# Altium schematic sheet 419-005-01-02_PG 05 - CV SoC - HPS DDR.SchDoc("419-005-01-02_PG 05 - CV SoC - HPS DDR")
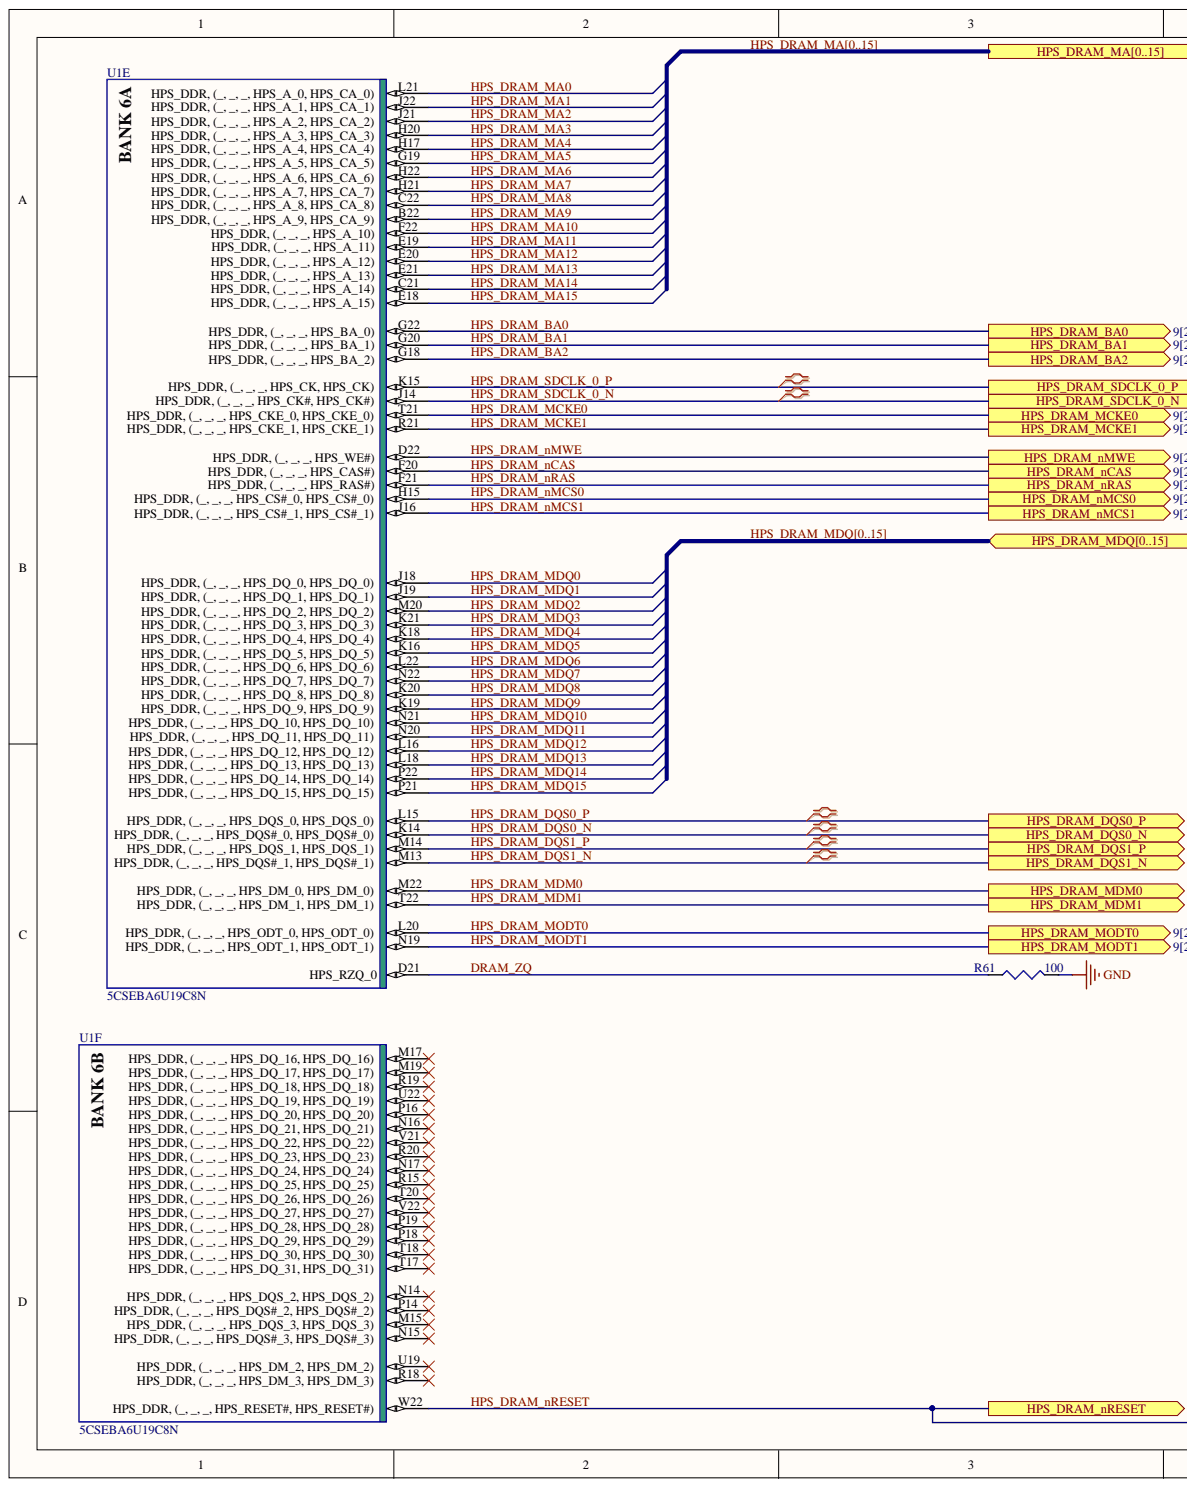
[diagram p0: 419-005-01-02_PG 05 - CV SoC - HPS DDR.SchDoc, left]
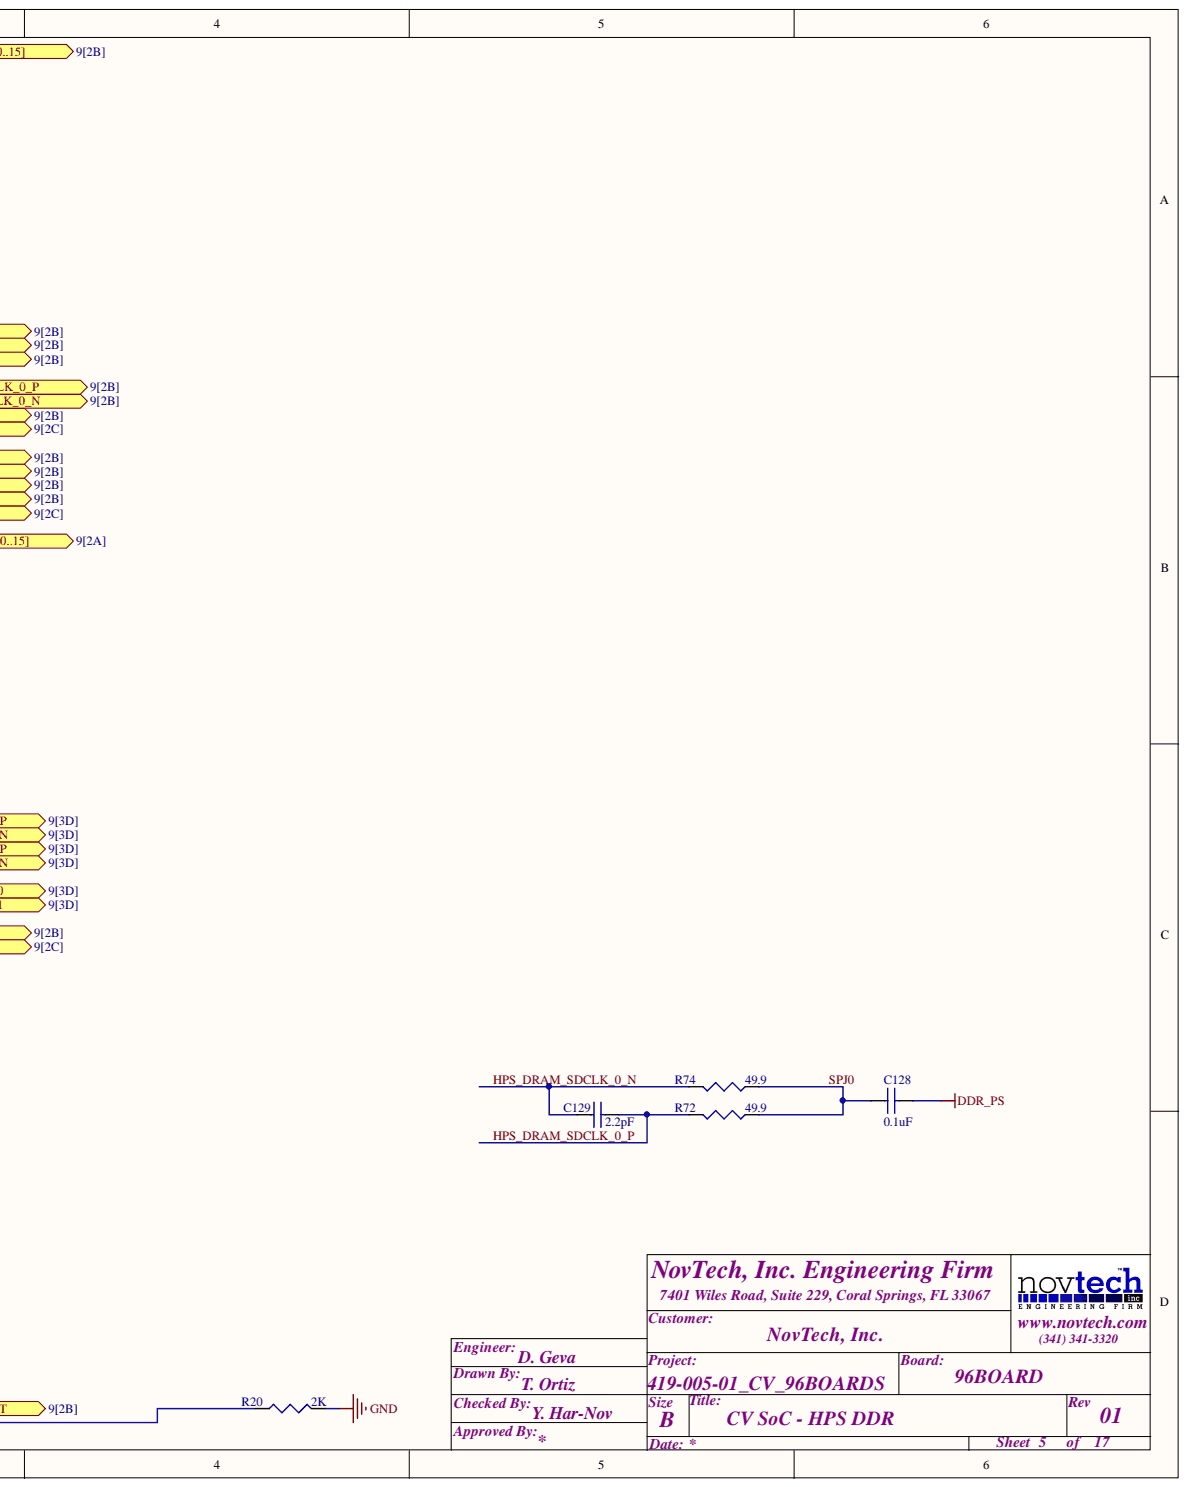
[diagram p1: 419-005-01-02_PG 05 - CV SoC - HPS DDR.SchDoc, right]

## Parts
C128  0.1uF  [0201_C]
C129  2.2pF  [0201_C]
R20  2K  [0201_R]
R61  100  [0201_R]
R72  49.9  [0402_R]
R74  49.9  [0402_R]
U1  5CSEBA6U19C8N  [BGA484C80P22X22_1900X1900X190]  (parts E,F)

## Ports
HPS_DRAM_BA0, HPS_DRAM_BA1, HPS_DRAM_BA2, HPS_DRAM_DQS0_N, HPS_DRAM_DQS0_P, HPS_DRAM_DQS1_N, HPS_DRAM_DQS1_P, HPS_DRAM_MA0, HPS_DRAM_MA1, HPS_DRAM_MA2, HPS_DRAM_MA3, HPS_DRAM_MA4, HPS_DRAM_MA5, HPS_DRAM_MA6, HPS_DRAM_MA7, HPS_DRAM_MA8, HPS_DRAM_MA9, HPS_DRAM_MA10, HPS_DRAM_MA11, HPS_DRAM_MA12, HPS_DRAM_MA13, HPS_DRAM_MA14, HPS_DRAM_MA15, HPS_DRAM_MA[0..15], HPS_DRAM_MCKE0, HPS_DRAM_MCKE1, HPS_DRAM_MDM0, HPS_DRAM_MDM1, HPS_DRAM_MDQ0, HPS_DRAM_MDQ1, HPS_DRAM_MDQ2, HPS_DRAM_MDQ3, HPS_DRAM_MDQ4, HPS_DRAM_MDQ5, HPS_DRAM_MDQ6, HPS_DRAM_MDQ7, HPS_DRAM_MDQ8, HPS_DRAM_MDQ9, HPS_DRAM_MDQ10, HPS_DRAM_MDQ11, HPS_DRAM_MDQ12, HPS_DRAM_MDQ13, HPS_DRAM_MDQ14, HPS_DRAM_MDQ15, HPS_DRAM_MDQ[0..15], HPS_DRAM_MODT0, HPS_DRAM_MODT1, HPS_DRAM_NCAS, HPS_DRAM_NMCS0, HPS_DRAM_NMCS1, HPS_DRAM_NMWE, HPS_DRAM_NRAS, HPS_DRAM_NRESET, HPS_DRAM_SDCLK_0_N, HPS_DRAM_SDCLK_0_P

## Nets
DDR_PS: C128.2
GND: R20.2 R61.2
DRAM_ZQ: R61.1 U1.D21(HPS_RZQ_0)
HPS_DRAM_BA0: U1.G22(HPS_DDR,_(_,__,__,_HPS_BA_0)) ; port HPS_DRAM_BA0
HPS_DRAM_BA1: U1.G20(HPS_DDR,_(_,__,__,_HPS_BA_1)) ; port HPS_DRAM_BA1
HPS_DRAM_BA2: U1.G18(HPS_DDR,_(_,__,__,_HPS_BA_2)) ; port HPS_DRAM_BA2
HPS_DRAM_DQS0_N: U1.K14(HPS_DDR,_(_,__,__,_HPS_DQS#_0,_HPS_DQS#_0)) ; port HPS_DRAM_DQS0_N
HPS_DRAM_DQS0_P: U1.L15(HPS_DDR,_(_,__,__,_HPS_DQS_0,_HPS_DQS_0)) ; port HPS_DRAM_DQS0_P
HPS_DRAM_DQS1_N: U1.M13(HPS_DDR,_(_,__,__,_HPS_DQS#_1,_HPS_DQS#_1)) ; port HPS_DRAM_DQS1_N
HPS_DRAM_DQS1_P: U1.M14(HPS_DDR,_(_,__,__,_HPS_DQS_1,_HPS_DQS_1)) ; port HPS_DRAM_DQS1_P
HPS_DRAM_MA0: U1.L21(HPS_DDR,_(_,__,__,_HPS_A_0,_HPS_CA_0)) ; port HPS_DRAM_MA[0..15]  [also labelled HPS_DRAM_MA]
HPS_DRAM_MA1: U1.J22(HPS_DDR,_(_,__,__,_HPS_A_1,_HPS_CA_1)) ; port HPS_DRAM_MA[0..15]  [also labelled HPS_DRAM_MA]
HPS_DRAM_MA2: U1.J21(HPS_DDR,_(_,__,__,_HPS_A_2,_HPS_CA_2)) ; port HPS_DRAM_MA[0..15]  [also labelled HPS_DRAM_MA]
HPS_DRAM_MA3: U1.H20(HPS_DDR,_(_,__,__,_HPS_A_3,_HPS_CA_3)) ; port HPS_DRAM_MA[0..15]  [also labelled HPS_DRAM_MA]
HPS_DRAM_MA4: U1.H17(HPS_DDR,_(_,__,__,_HPS_A_4,_HPS_CA_4)) ; port HPS_DRAM_MA[0..15]  [also labelled HPS_DRAM_MA]
HPS_DRAM_MA5: U1.G19(HPS_DDR,_(_,__,__,_HPS_A_5,_HPS_CA_5)) ; port HPS_DRAM_MA[0..15]  [also labelled HPS_DRAM_MA]
HPS_DRAM_MA6: U1.H22(HPS_DDR,_(_,__,__,_HPS_A_6,_HPS_CA_6)) ; port HPS_DRAM_MA[0..15]  [also labelled HPS_DRAM_MA]
HPS_DRAM_MA7: U1.H21(HPS_DDR,_(_,__,__,_HPS_A_7,_HPS_CA_7)) ; port HPS_DRAM_MA[0..15]  [also labelled HPS_DRAM_MA]
HPS_DRAM_MA8: U1.C22(HPS_DDR,_(_,__,__,_HPS_A_8,_HPS_CA_8)) ; port HPS_DRAM_MA[0..15]  [also labelled HPS_DRAM_MA]
HPS_DRAM_MA9: U1.B22(HPS_DDR,_(_,__,__,_HPS_A_9,_HPS_CA_9)) ; port HPS_DRAM_MA[0..15]  [also labelled HPS_DRAM_MA]
HPS_DRAM_MA10: U1.F22(HPS_DDR,_(_,__,__,_HPS_A_10)) ; port HPS_DRAM_MA[0..15]  [also labelled HPS_DRAM_MA]
HPS_DRAM_MA11: U1.E19(HPS_DDR,_(_,__,__,_HPS_A_11)) ; port HPS_DRAM_MA[0..15]  [also labelled HPS_DRAM_MA]
HPS_DRAM_MA12: U1.E20(HPS_DDR,_(_,__,__,_HPS_A_12)) ; port HPS_DRAM_MA[0..15]  [also labelled HPS_DRAM_MA]
HPS_DRAM_MA13: U1.E21(HPS_DDR,_(_,__,__,_HPS_A_13)) ; port HPS_DRAM_MA[0..15]  [also labelled HPS_DRAM_MA]
HPS_DRAM_MA14: U1.C21(HPS_DDR,_(_,__,__,_HPS_A_14)) ; port HPS_DRAM_MA[0..15]  [also labelled HPS_DRAM_MA]
HPS_DRAM_MA15: U1.E18(HPS_DDR,_(_,__,__,_HPS_A_15)) ; port HPS_DRAM_MA[0..15]  [also labelled HPS_DRAM_MA]
HPS_DRAM_MCKE0: U1.T21(HPS_DDR,_(_,__,__,_HPS_CKE_0,_HPS_CKE_0)) ; port HPS_DRAM_MCKE0
HPS_DRAM_MCKE1: U1.R21(HPS_DDR,_(_,__,__,_HPS_CKE_1,_HPS_CKE_1)) ; port HPS_DRAM_MCKE1
HPS_DRAM_MDM0: U1.M22(HPS_DDR,_(_,__,__,_HPS_DM_0,_HPS_DM_0)) ; port HPS_DRAM_MDM0
HPS_DRAM_MDM1: U1.T22(HPS_DDR,_(_,__,__,_HPS_DM_1,_HPS_DM_1)) ; port HPS_DRAM_MDM1
HPS_DRAM_MDQ0: U1.J18(HPS_DDR,_(_,__,__,_HPS_DQ_0,_HPS_DQ_0)) ; port HPS_DRAM_MDQ[0..15]  [also labelled HPS_DRAM_MDQ]
HPS_DRAM_MDQ1: U1.J19(HPS_DDR,_(_,__,__,_HPS_DQ_1,_HPS_DQ_1)) ; port HPS_DRAM_MDQ[0..15]  [also labelled HPS_DRAM_MDQ]
HPS_DRAM_MDQ2: U1.M20(HPS_DDR,_(_,__,__,_HPS_DQ_2,_HPS_DQ_2)) ; port HPS_DRAM_MDQ[0..15]  [also labelled HPS_DRAM_MDQ]
HPS_DRAM_MDQ3: U1.K21(HPS_DDR,_(_,__,__,_HPS_DQ_3,_HPS_DQ_3)) ; port HPS_DRAM_MDQ[0..15]  [also labelled HPS_DRAM_MDQ]
HPS_DRAM_MDQ4: U1.K18(HPS_DDR,_(_,__,__,_HPS_DQ_4,_HPS_DQ_4)) ; port HPS_DRAM_MDQ[0..15]  [also labelled HPS_DRAM_MDQ]
HPS_DRAM_MDQ5: U1.K16(HPS_DDR,_(_,__,__,_HPS_DQ_5,_HPS_DQ_5)) ; port HPS_DRAM_MDQ[0..15]  [also labelled HPS_DRAM_MDQ]
HPS_DRAM_MDQ6: U1.L22(HPS_DDR,_(_,__,__,_HPS_DQ_6,_HPS_DQ_6)) ; port HPS_DRAM_MDQ[0..15]  [also labelled HPS_DRAM_MDQ]
HPS_DRAM_MDQ7: U1.N22(HPS_DDR,_(_,__,__,_HPS_DQ_7,_HPS_DQ_7)) ; port HPS_DRAM_MDQ[0..15]  [also labelled HPS_DRAM_MDQ]
HPS_DRAM_MDQ8: U1.K20(HPS_DDR,_(_,__,__,_HPS_DQ_8,_HPS_DQ_8)) ; port HPS_DRAM_MDQ[0..15]  [also labelled HPS_DRAM_MDQ]
HPS_DRAM_MDQ9: U1.K19(HPS_DDR,_(_,__,__,_HPS_DQ_9,_HPS_DQ_9)) ; port HPS_DRAM_MDQ[0..15]  [also labelled HPS_DRAM_MDQ]
HPS_DRAM_MDQ10: U1.N21(HPS_DDR,_(_,__,__,_HPS_DQ_10,_HPS_DQ_10)) ; port HPS_DRAM_MDQ[0..15]  [also labelled HPS_DRAM_MDQ]
HPS_DRAM_MDQ11: U1.N20(HPS_DDR,_(_,__,__,_HPS_DQ_11,_HPS_DQ_11)) ; port HPS_DRAM_MDQ[0..15]  [also labelled HPS_DRAM_MDQ]
HPS_DRAM_MDQ12: U1.L16(HPS_DDR,_(_,__,__,_HPS_DQ_12,_HPS_DQ_12)) ; port HPS_DRAM_MDQ[0..15]  [also labelled HPS_DRAM_MDQ]
HPS_DRAM_MDQ13: U1.L18(HPS_DDR,_(_,__,__,_HPS_DQ_13,_HPS_DQ_13)) ; port HPS_DRAM_MDQ[0..15]  [also labelled HPS_DRAM_MDQ]
HPS_DRAM_MDQ14: U1.P22(HPS_DDR,_(_,__,__,_HPS_DQ_14,_HPS_DQ_14)) ; port HPS_DRAM_MDQ[0..15]  [also labelled HPS_DRAM_MDQ]
HPS_DRAM_MDQ15: U1.P21(HPS_DDR,_(_,__,__,_HPS_DQ_15,_HPS_DQ_15)) ; port HPS_DRAM_MDQ[0..15]  [also labelled HPS_DRAM_MDQ]
HPS_DRAM_MODT0: U1.L20(HPS_DDR,_(_,__,__,_HPS_ODT_0,_HPS_ODT_0)) ; port HPS_DRAM_MODT0
HPS_DRAM_MODT1: U1.N19(HPS_DDR,_(_,__,__,_HPS_ODT_1,_HPS_ODT_1)) ; port HPS_DRAM_MODT1
HPS_DRAM_NCAS: U1.F20(HPS_DDR,_(_,__,__,_HPS_CAS#)) ; port HPS_DRAM_NCAS
HPS_DRAM_NMCS0: U1.H15(HPS_DDR,_(_,__,__,_HPS_CS#_0,_HPS_CS#_0)) ; port HPS_DRAM_NMCS0
HPS_DRAM_NMCS1: U1.J16(HPS_DDR,_(_,__,__,_HPS_CS#_1,_HPS_CS#_1)) ; port HPS_DRAM_NMCS1
HPS_DRAM_NMWE: U1.D22(HPS_DDR,_(_,__,__,_HPS_WE#)) ; port HPS_DRAM_NMWE
HPS_DRAM_NRAS: U1.F21(HPS_DDR,_(_,__,__,_HPS_RAS#)) ; port HPS_DRAM_NRAS
HPS_DRAM_NRESET: R20.1 U1.W22(HPS_DDR,_(_,__,__,_HPS_RESET#,_HPS_RESET#)) ; port HPS_DRAM_NRESET
HPS_DRAM_SDCLK_0_N: C129.1 R74.2 U1.J14(HPS_DDR,_(_,__,__,_HPS_CK#,_HPS_CK#)) ; port HPS_DRAM_SDCLK_0_N
HPS_DRAM_SDCLK_0_P: C129.2 R72.2 U1.K15(HPS_DDR,_(_,__,__,_HPS_CK,_HPS_CK)) ; port HPS_DRAM_SDCLK_0_P
SPJ0: C128.1 R72.1 R74.1

Not connected: U1 M15(HPS_DDR,_(_,__,__,_HPS_DQS_3,_HPS_DQS_3)) M17(HPS_DDR,_(_,__,__,_HPS_DQ_16,_HPS_DQ_16)) M19(HPS_DDR,_(_,__,__,_HPS_DQ_17,_HPS_DQ_17)) N14(HPS_DDR,_(_,__,__,_HPS_DQS_2,_HPS_DQS_2)) N15(HPS_DDR,_(_,__,__,_HPS_DQS#_3,_HPS_DQS#_3)) N16(HPS_DDR,_(_,__,__,_HPS_DQ_21,_HPS_DQ_21)) N17(HPS_DDR,_(_,__,__,_HPS_DQ_24,_HPS_DQ_24)) P14(HPS_DDR,_(_,__,__,_HPS_DQS#_2,_HPS_DQS#_2)) P16(HPS_DDR,_(_,__,__,_HPS_DQ_20,_HPS_DQ_20)) P18(HPS_DDR,_(_,__,__,_HPS_DQ_29,_HPS_DQ_29)) P19(HPS_DDR,_(_,__,__,_HPS_DQ_28,_HPS_DQ_28)) R15(HPS_DDR,_(_,__,__,_HPS_DQ_25,_HPS_DQ_25)) R18(HPS_DDR,_(_,__,__,_HPS_DM_3,_HPS_DM_3)) R19(HPS_DDR,_(_,__,__,_HPS_DQ_18,_HPS_DQ_18)) R20(HPS_DDR,_(_,__,__,_HPS_DQ_23,_HPS_DQ_23)) T17(HPS_DDR,_(_,__,__,_HPS_DQ_31,_HPS_DQ_31)) T18(HPS_DDR,_(_,__,__,_HPS_DQ_30,_HPS_DQ_30)) T20(HPS_DDR,_(_,__,__,_HPS_DQ_26,_HPS_DQ_26)) U19(HPS_DDR,_(_,__,__,_HPS_DM_2,_HPS_DM_2)) U22(HPS_DDR,_(_,__,__,_HPS_DQ_19,_HPS_DQ_19)) V21(HPS_DDR,_(_,__,__,_HPS_DQ_22,_HPS_DQ_22)) V22(HPS_DDR,_(_,__,__,_HPS_DQ_27,_HPS_DQ_27))

## Library data of the parts
C128 = CAP_0201: Capasitor 0201 footprint; Component Kind: Standard; Library Name: PASSIVES.SchLib
C129 = CAP_0201: Capasitor 0201 footprint; MFG: TDK; Component Kind: Standard; DigiKey: 445-4606-1-ND; Library Name: PASSIVES.SchLib; RoHS: Yes
R20 = RESISOTR 0201: Resistor 0201 footprint; PART: ERJ-1GEF2001C; MFG: Panasonic; Component Kind: Standard; Digikey: P2.00KABCT-ND; Library Name: PASSIVES.SchLib; RoHS: Yes; TYPE: SMT
R61 = RESISOTR 0201: Resistor 0201 footprint; PART: RMCF0201FT100R; MFG: Stackpole; TEMP: -55°C t0 +125°C; Component Kind: Standard; DigiKey: RMCF0201FT100RCT-ND; Library Name: PASSIVES.SchLib; RoHS: Yes; TYPE: SMT
R72 R74 = RESISOTR 0402: Resistor 0402 footprint; Component Kind: Standard; Library Name: PASSIVES.SchLib
U1 = 5CSEBA6U19C8N: Cyclone V SE Family FPGA, 1.07 to 1.13 V Supply, 66 I/O Pins, 6 PLLs, 484-Pin UBGA, Speed Grade 7, Commercial Grade, RoHS Compliant; Manufacturer: Altera; Logic Elements (LE) (K): 110; Speed Grade: 8; Code_JEDEC: MO-275; Component Kind: Standard; ComponentLink1Description: Manufacturer URL; ComponentLink1URL: http://www.altera.com; ComponentLink2Description: Datasheet; ComponentLink2URL: http://www.altera.com/literature/hb/cyclone-v/cv_51002.pdf; ComponentLink3Description: Package Specification; ComponentLink3URL: http://www.altera.com/devicepackaging/04R00436-01.pdf; ComponentLink4Description: Device Handbook URL; ComponentLink4URL: http://www.altera.com/literature/hb/cyclone-v/cyclone5_handbook.pdf; ComponentLink5Description: Device Pin-Out URL; ComponentLink5URL: http://www.altera.com/literature/dp/cyclone-v/5CSEBA6.xls; ComponentLink6Description: Product URL; ComponentLink6URL: http://www.buyaltera.com/scripts/partsearch.dll?Detail&name=5CSEBA6U19C8N-ND; DatasheetVersion: Rev. 3.4; Device Group: 5CSEBA6; Library Name: IC_SchLib.SCHLIB; Mounting Technology: Surface Mount; NexusDeviceID: 5CSEBA6U19C8N; PackageDescription: 484-Pin Ultra FineLine Ball-Grid Array (UBGA), Body 19 x 19 mm, Pitch 0.8 mm; PackageReference: UBGA484_A-190; PackageVersion: Rev. 1.0, 12/2011; PartNumber: 5CSEBA6U19C8N; RoHS: RoHS Compliant; Subcategory: Cyclone V SE; Supplier 1: Digi-Key; Supplier 2: Mouser; Supplier Part Number 1: 5CSEBA6U19C8N-ND; Supplier Part Number 2: 989-5CSEBA6U19C8N; Voltage Supply: 1.07 to 1.13 V
